# Altium schematic sheet Ruddock2_0_1_Power.SchDoc
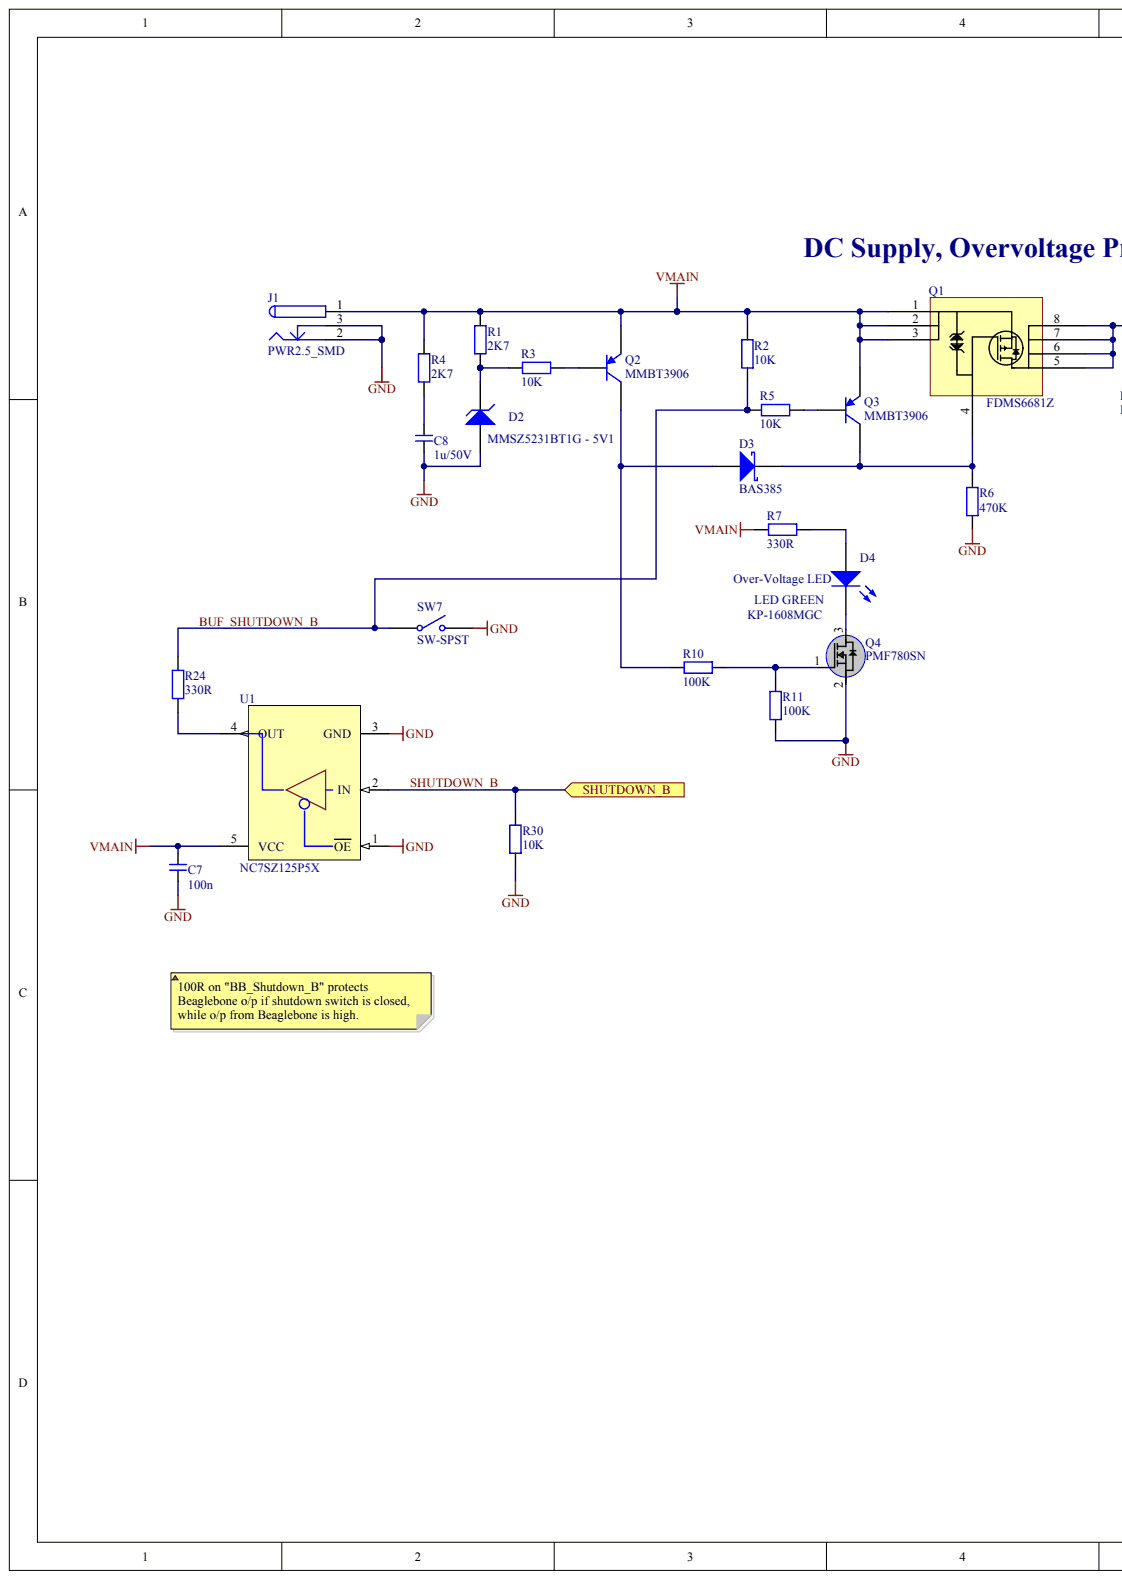
[diagram p0: Ruddock2_0_1_Power.SchDoc, left]
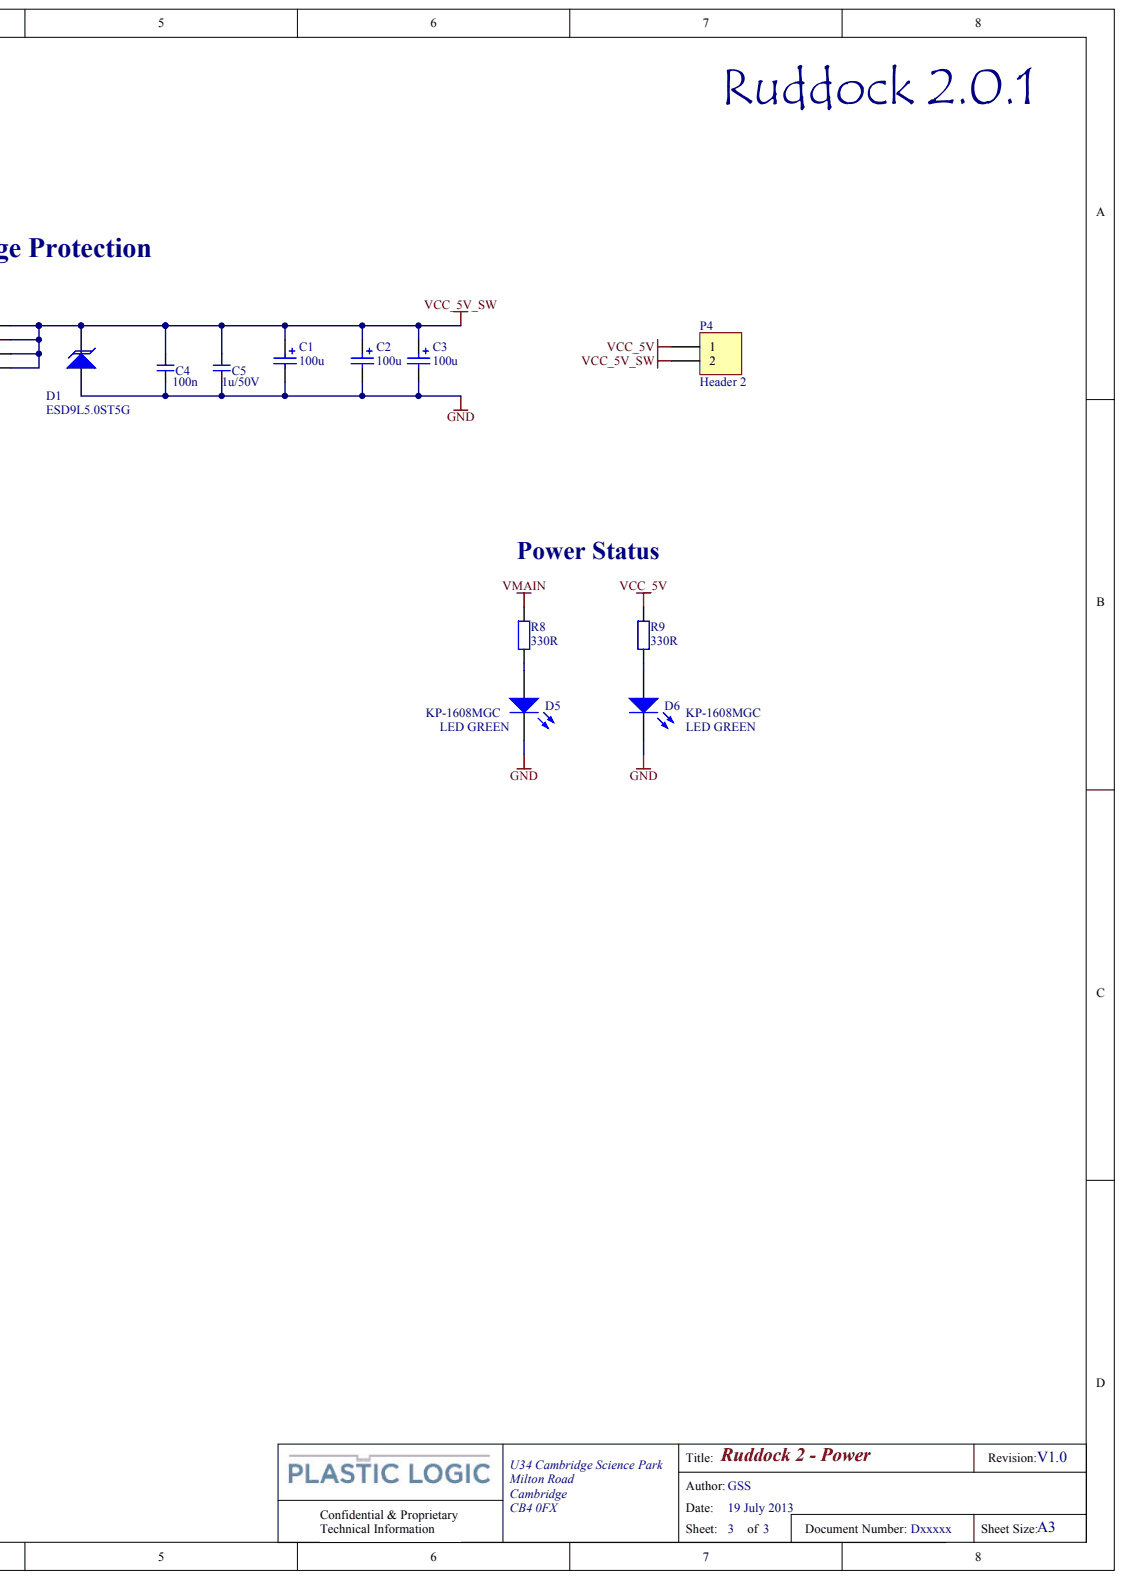
[diagram p1: Ruddock2_0_1_Power.SchDoc, right]

## Parts
C1  100u
C2  100u
C3  100u
C4  100n
C5  1u/50V
C7  100n
C8  1u/50V
D1  ESD9L5.0ST5G
D2  ManufacturerPN: MMSZ5231BT1G  (hidden comment: MMSZ5231BT1G - 5V1)
D3  BAS385
D4  value LED GREEN  ManufacturerPN: KP-1608MGC  (hidden comment: LED2)
D5  value LED GREEN  ManufacturerPN: KP-1608MGC  (hidden comment: LED2)
D6  value LED GREEN  ManufacturerPN: KP-1608MGC  (hidden comment: LED2)
J1  PWR2.5_SMD
P4  Header 2
Q1  FDMS6681Z
Q2  MMBT3906
Q3  MMBT3906
Q4  PMF780SN
R1  2K7
R2  10K
R3  10K
R4  2K7
R5  10K
R6  470K
R7  330R
R8  330R
R9  330R
R10  100K
R11  100K
R24  330R
R30  10K
SW7  SW-SPST
U1  NC7SZ125P5X

## Ports
SHUTDOWN_B

## Nets
GND: C1.2 C2.2 C3.2 C4.2 C5.1 C7.2 C8.2 D1.2 D2.2 D5.2 D6.2 J1.2 J1.3 Q4.2 R6.1 R11.2 R30.1 SW7.2 U1.1(OE) U1.3(GND)
VCC_5V: P4.1 R9.1
VCC_5V_SW: C1.1 C2.1 C3.1 C4.1 C5.2 D1.1 P4.2 Q1.5 Q1.6 Q1.7 Q1.8
VMAIN: C7.1 J1.1 Q1.1 Q1.2 Q1.3 Q2.2 Q3.2 R1.1 R2.2 R4.1 R7.2 R8.1 U1.5(VCC)
BUF_SHUTDOWN_B: R2.1 R5.2 R24.1 SW7.1
SHUTDOWN_B: R30.2 U1.2(IN) ; port SHUTDOWN_B
NetC8_1: C8.1 R4.2
NetD2_1: D2.1 R1.2 R3.2
NetD3_1: D3.1 Q2.3 R10.2
NetD3_2: D3.2 Q1.4 Q3.3 R6.2
NetD4_1: D4.1 R7.1
NetD4_2: D4.2 Q4.3
NetD5_1: D5.1 R8.2
NetD6_1: D6.1 R9.2
NetQ2_1: Q2.1 R3.1
NetQ3_1: Q3.1 R5.1
NetQ4_1: Q4.1 R10.1 R11.1
NetR24_2: R24.2 U1.4(OUT)

## Library data of the parts
C1-C3 = (no symbol name): Manufacturer: VISHAY SPRAGUE; ManufacturerPN: 293D107X9016D2TE3; Fitted: I; Tolerance: 10%; Order Code: 1754193; Value: 100u; Supplier: Farnell
C4 = (no symbol name): Manufacturer: TDK Corporation; ManufacturerPN: C1005X7R1C104K050BC; Tolerance: 10%; Fitted: I; Order Code: 445-4952-1-ND; Value: 100n; Supplier: Digi-Key
C5 C8 = (no symbol name): Manufacturer: MURATA; ManufacturerPN: GRM21BR71H105KA12L; Tolerance: 10%; Fitted: I; Order Code: 173-5541; Value: 1u/50V; Supplier: Farnell
C7 = (no symbol name): Manufacturer: AVX; ManufacturerPN: 06033C104KAZ2A; Fitted: I; Order Code: 183 3840; Tolerance: *; Value: 100n; Supplier: Farnell
D1 = (no symbol name): Manufacturer: On Semi; Fitted: I; Order Code: 1651639; ManufacturerPN: ESD9L5.0ST5G; Value: ESD9L5.0ST5G; Supplier: Farnell
D2 = (no symbol name): Manufacturer: ON Semiconductor; Fitted: I; Order Code: 1431273; Value: MMSZ5231BT1G - 5V1; Supplier: Farnell
D3 = (no symbol name): Manufacturer: Vishay; Fitted: I; Order Code: 1469395; Value: BAS385; Supplier: Farnell; ManufacturerPN: BAS385
D4-D6 = (no symbol name): Manufacturer: KINGBRIGHT; Order Code: 852-9825; Fitted: I; Supplier: Farnell
J1 = (no symbol name): Manufacturer: Cliff Electronic Components; ManufacturerPN: DC-10AS; Fitted: I; Value: 2.1mm pin DC socket; Order Code: 1889309; Supplier: Farnell
P4 = (no symbol name): Manufacturer: Samtec; ManufacturerPN: HTSW-102-07-S-S; Fitted: I; Value: HTSW-102-07-S-S; Order Code: HTSW-102-07-S-S; Supplier: Toby Electronics
Q1 = (no symbol name): Manufacturer: Fairchild; Fitted: I; Order Code: 2083283; ManufacturerPN: FDMS6681Z; Value: FDMS6681Z; Supplier: Farnell
Q2 Q3 = (no symbol name): Manufacturer: Fairchild; Order Code: 984-6832; Fitted: I; ManufacturerPN: MMBT3906; Value: MMBT3906; Supplier: Farnell
Q4 = (no symbol name): Manufacturer: NXP; Fitted: I; Order Code: 1894712; Value: PMF780SN; ManufacturerPN: PMF780SN; Supplier: Farnell
R1 R4 = (no symbol name): Manufacturer: VISHAY DRALORIC; ManufacturerPN: CRCW06032K70FKEA; Tolerance: 1%; Fitted: I; Order Code: 1469768; Value: 2K7; Supplier: Farnell
R2 R3 R5 R30 = (no symbol name): Manufacturer: VISHAY DRALORIC; ManufacturerPN: CRCW060310K0FKEA; Tolerance: 1%; Fitted: I; Order Code: 1469748; Value: 10K; Supplier: Farnell
R6 = (no symbol name): Manufacturer: VISHAY DRALORIC; ManufacturerPN: CRCW0603470KFKEA; Tolerance: 1%; Fitted: I; Order Code: 1469814; Value: 470K; Supplier: Farnell
R7-R9 R24 = (no symbol name): Manufacturer: VISHAY DRALORIC; ManufacturerPN: CRCW0603330RFKEA; Tolerance: 1%; Fitted: I; Order Code: 1469803; Value: 330R; Supplier: Farnell
R10 R11 = (no symbol name): Manufacturer: PANASONIC; ManufacturerPN: ERA3AEB104V; Tolerance: 0.1%; Fitted: I; Order Code: 157-7632; Value: 100K; Supplier: Farnell
SW7 = (no symbol name): Manufacturer: EAO; ManufacturerPN: 09-03290.01; Fitted: I; Order Code: 674345; Value: 09-03290.01; Supplier: Farnell
U1 = (no symbol name): Manufacturer: Fairchild; Fitted: I; Order code: 1417645; ManufacturerPN: NC7SZ125P5X; Supplier: Farnell; Value: NC7SZ125P5X
